# Altium schematic sheet CANBoard.SchDoc
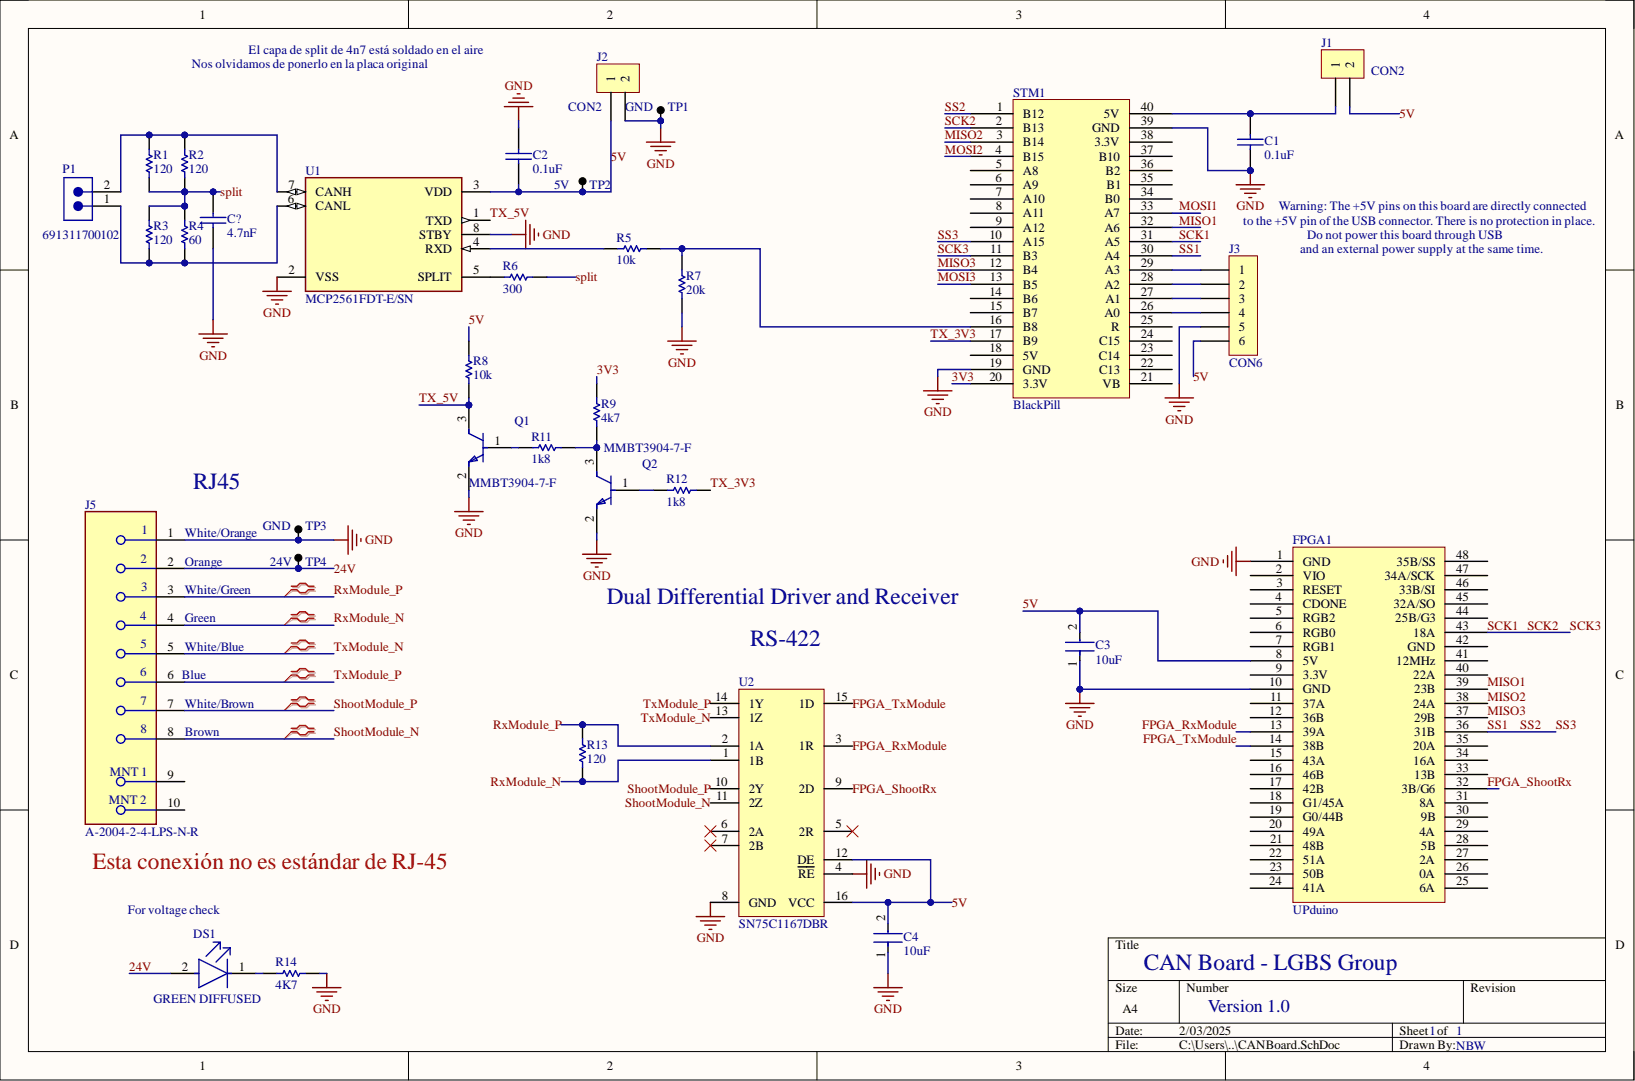
[diagram p0: CANBoard.SchDoc]

## Parts
C1  0.1uF  [0805]
C2  0.1uF  [0805]
C3  10uF  [FP-CL31B106KBHNNNE-IPC_A]
C4  10uF  [FP-CL31B106KBHNNNE-IPC_A]
C?  4.7nF  [0805]
DS1  GREEN DIFFUSED  [FP-LY_R976-MFG]
FPGA1  UPduino  [FPGA]
J1  CON2  [SIP2]
J2  CON2  [SIP2]
J3  CON6  [SIP6]
J5  A-2004-2-4-LPS-N-R  [FP-A-2004-2-4-LPS-N-R-MFG]
P1  691311700102  [691311700102]
Q1  MMBT3904-7-F  [FP-SOT23-IPC_A]
Q2  MMBT3904-7-F  [FP-SOT23-IPC_A]
R1  120  [0805]
R2  120  [0805]
R3  120  [0805]
R4  60  [0805]
R5  10k  [0805]
R6  300  [0805]
R7  20k  [0805]
R8  10k  [0805]
R9  4k7  [0805]
R11  1k8  [0805]
R12  1k8  [0805]
R13  120  [0805]
R14  4K7  [0805]
STM1  BlackPill  [BLACKPILL]
TP1  GND  [TPTH]
TP2  5V  [TPTH]
TP3  GND  [TPTH]
TP4  24V  [TPTH]
U1  MCP2561FDT-E/SN  [FP-C04-057-SN-MFG]
U2  SN75C1167DBR  [MC34050]

## Nets
GND: C1.2 C2.1 C3.1 C4.1 C?.2 FPGA1.1(GND) FPGA1.10(GND) J2.2 J3.5 J5.1 Q1.2 Q2.2 R7.2 R14.2 STM1.19(GND) STM1.39(GND) TP1.1 TP3.1 U1.2(VSS) U1.8(STBY) U2.4(RE) U2.8(GND)
3V3: R9.2 STM1.20(3.3V)
5V: C2.2 C3.2 C4.2 FPGA1.8(5V) J1.2 J2.1 J3.6 R8.2 TP2.1 U1.3(VDD) U2.12(DE) U2.16(VCC)
24V: DS1.2 J5.2 TP4.1
FPGA_RxModule: FPGA1.13(39A) U2.3(1R)
FPGA_ShootRx: FPGA1.32(3B/G6) U2.9(2D)
FPGA_TxModule: FPGA1.14(38B) U2.15(1D)
MISO1: FPGA1.39(23B) STM1.32(A6)
MISO2: FPGA1.38(24A) STM1.3(B14)
MISO3: FPGA1.37(29B) STM1.12(B4)
MOSI1: STM1.33(A7)
MOSI2: STM1.4(B15)
MOSI3: STM1.13(B5)
RxModule_N: J5.4 R13.1 U2.1(1B)
RxModule_P: J5.3 R13.2 U2.2(1A)
SCK1: FPGA1.43(18A) STM1.2(B13) STM1.11(B3) STM1.31(A5)
ShootModule_N: J5.8 U2.11(2Z)
ShootModule_P: J5.7 U2.10(2Y)
split: C?.1 R1.1 R2.1 R3.2 R4.2 R6.1
SS1: FPGA1.36(31B) STM1.1(B12) STM1.10(A15) STM1.30(A4)
TX_3V3: R12.2 STM1.17(B9)
TX_5V: Q1.3 R8.1 U1.1(TXD)
TxModule_N: J5.5 U2.13(1Z)
TxModule_P: J5.6 U2.14(1Y)
NetC1_1: C1.1 J1.1 STM1.40(5V)
NetDS1_1: DS1.1 R14.1
NetJ3_1: J3.1 STM1.29(A3)
NetJ3_2: J3.2 STM1.28(A2)
NetJ3_3: J3.3 STM1.27(A1)
NetJ3_4: J3.4 STM1.26(A0)
NetP1_1: P1.1 R3.1 R4.1 U1.6(CANL)
NetP1_2: P1.2 R1.2 R2.2 U1.7(CANH)
NetQ1_1: Q1.1 R11.2
NetQ2_1: Q2.1 R12.1
NetQ2_3: Q2.3 R9.1 R11.1
NetR5_1: R5.1 U1.4(RXD)
NetR5_2: R5.2 R7.1 STM1.16(B8)
NetR6_2: R6.2 U1.5(SPLIT)

Not connected: FPGA1 2(VIO) 3(RESET) 4(CDONE) 5(RGB2) 6(RGB0) 7(RGB1) 9(3.3V) 11(37A) 12(36B) 15(43A) 16(46B) 17(42B) 18(G1/45A) 19(G0/44B) 20(49A) 21(48B) 22(51A) 23(50B) 24(41A) 25(6A) 26(0A) 27(2A) 28(5B) 29(4A) 30(9B) 31(8A) 33(13B) 34(16A) 35(20A) 40(22A) 41(12MHz) 42(GND) 44(25B/G3) 45(32A/SO) 46(33B/SI) 47(34A/SCK) 48(35B/SS); J5 9 10; STM1 5(A8) 6(A9) 7(A10) 8(A11) 9(A12) 14(B6) 15(B7) 18(5V) 21(VB) 22(C13) 23(C14) 24(C15) 25(R) 34(B0) 35(B1) 36(B2) 37(B10) 38(3.3V); U2 5(2R) 6(2A) 7(2B)

## Library data of the parts
C1 C2 C? = CAP: Component Kind: Standard; Library Name: LIBEBAL.IntLib
C3 C4 = CMP-2000-07530-2: CAP CER 10UF 50V X7R 1206 -CL31B106KBHNNNE; Manufacturer: Samsung; Material: Ceramic; Temperature Coefficient: 15%; Tolerance: 10%; Automotive: No; Capacitance: 10uF; Category: Cap; Component Kind: Standard; ComponentLink2Description: Datasheet; ComponentLink2URL: http://www.samsungsem.com/global/front/downloadcms.do?path=%2F%2Fglobal%2F%2Fsupport%2F%2Fproduct-search%2F%2Fmlcc%2F%2F__icsFiles%2F%2Fafieldfile%2F%2F2019%2F%2F06%2F%2F18&fileName=CL31B106KBHNNNE-19.pdf; Device Class L1: Passive Components; Device Class L2: Capacitors; Device Class L3: Ceramic Capacitors; Digikey Description: CAP CER 10UF 50V X7R 1206; Height: 1.8mm; Lead Free: Yes; Library Name: Altium Content Vault; Manufacturer Part Number: CL31B106KBHNNNE; Octopart: https://octopart.com/cl31b106kbhnnne-samsung-30507377; Package: CL31B106KBHNNNE; RoHS: TRUE; Temperature: -55°C to +125°C; Temperature Characteristic: X7R; Temperature Range High: +125°C; Temperature Range Low: -55°C; Value: 10uF; Voltage Rating: 50V
DS1 = CMP-62016-000040-1: LED YELLOW DIFFUSED 0805 SMD - LY R976-PS-36; Dominant Wavelength: 588nm; Forward Current: 25mA; Forward Voltage: 2.5V; Lens Color: White; Lens Size: 1.25mm; Lens Style: Flat; Luminous Flux: 0.735lm; Luminous Intensity: 280mcd; Number of Elements: 1; Power Dissipation: 65mW; Reverse Voltage: 12V; Test Current: 20mA; Viewing Angle: 160°; Case Code (Imperial): 0805; Case Code (Metric): 2012; Case/Package: 0805; Color: Yellow; Component Kind: Standard; Depth: 1.35mm; Illumination Color: Yellow; Length: 2.1mm; Lens Transparency: Diffused; Library Name: Altium Content Vault; Max Operating Temperature: 85°C; Min Operating Temperature: -30°C; Mount: Surface Mount; Number of LEDs: 1; Number of Pins: 2; Package Quantity: 16000; Packaging: Tape and Reel; Radiation Hardening: No; Width: 1.25mm
FPGA1 = FPGA: upduino v3.0; Component Kind: Standard; Library Name: MCSI.SchLib
J5 = CMP-39752-000001-1: CONN MOD JACK 8P8C R/A SHIELDED; Direction: Down; Gender: Female; Max Current Rating: 1.5A; Max Voltage Rating (AC): 120V; Number of Contacts: 8; Number of Ports: 1; Pitch: 2.54mm; Component Kind: Standard; Connector Type: Jack; Contact Material: Bronze; Contact Plating: Gold; Housing Material: PBT; Lead Free: Lead Free; Library Name: Altium Content Vault; Max Operating Temperature: 85°C; Min Operating Temperature: -40°C; Mount: Through Hole; Number of Rows: 1; Orientation: Right Angle; Package Quantity: 100; Packaging: Tray; RoHS Compliant: Yes; Series: -; Shielding: Shielded; Termination: Solder
P1 = CMP-1502-02033-2: NexarPartId: 18818310; Current: 20A; Gender: Male; Number of Contacts: 2; Number of Levels: 1; Pitch: 5.0038mm; Voltage: 300V; Color: Green; Component Kind: Standard; Contact Material: Brass; Height: 12mm; Lead Pitch: 5mm; Length: 8.5mm; Library Name: Altium Content Vault; Mount: Through Hole; Number of Pins: 2; Number of Positions Per Level: 2; Number of Rows: 1; Orientation: Vertical; Packaging: Box; REACH SVHC: Unknown; RoHS: Compliant; Termination: Solder; Width: 12mm
Q1 Q2 = CMP-2000-04931-2: TRANS NPN 40V 0.2A SMD SOT23-3; Collector Base Voltage (VCBO): 60V; Collector Emitter Saturation Voltage: 300mV; Continuous Collector Current: 200mA; Element Configuration: Single; Emitter Base Voltage (VEBO): 6V; Frequency: 300MHz; hFE Min: 100; Max Power Dissipation: 300mW; Number of Elements: 1; Power Dissipation: 350mW; Case/Package: SOT-23; Collector Emitter Breakdown Voltage: 40V; Collector Emitter Voltage (VCEO): 40V; Component Kind: Standard; Contact Plating: Tin; Gain Bandwidth Product: 300MHz; Height: 1mm; Lead Free: Lead Free; Length: 3.05mm; Library Name: Altium Content Vault; Max Breakdown Voltage: 40V; Max Collector Current: 200mA; Max Frequency: 300MHz; Max Operating Temperature: 150°C; Min Operating Temperature: -55°C; Mount: Surface Mount; Number of Pins: 3; Package Quantity: 3000; Packaging: Tape and Reel; Polarity: NPN; Radiation Hardening: No; REACH SVHC: No SVHC; Transition Frequency: 300MHz; Voltage Rating (DC): 40V; Weight: 0.000282oz; Width: 1.4mm
R1-R9 R11-R13 = RES: Component Kind: Standard; Library Name: LIBEBAL.IntLib
R14 = RES: 1/8W; Component Kind: Standard; Library Name: LIBEBAL.IntLib
STM1 = STM32: STM32F411 BLACKPILL EVAL BRD; Component Kind: Standard; Library Name: MCSI.SchLib
TP1-TP4 = TP: Component Kind: Standard; Library Name: LIBEBAL.IntLib
U1 = CMP-06279-000064-1: IC TRANSCEIVER 1/1 8SOIC; Data Rate: 8Mbps; Max Supply Voltage: 5.5V; Min Supply Voltage: 4.5V; Number of Transceivers: 1; Operating Supply Current: 70mA; Receiver Hysteresis: 200mV; Case/Package: SOIC; Component Kind: Standard; Library Name: Altium Content Vault; Max Operating Temperature: 125°C; Min Operating Temperature: -40°C; Mount: Surface Mount; Number of Pins: 8; Package Quantity: 3300; Packaging: Tape and Reel; RoHS Compliant: Yes; Series: -; Weight: 0.019048oz
U2 = CMP-0310-00497-2: Dual Differential Driver and Receiver, 2 TX / 2 RX, 5V, 0 to 70 degC, 16-Pin SSOP (DB), Green (RoHS & no Sb/Br); Manufacturer: Texas Instruments; Drivers Per Package: 2; ICC(Max)(mA): 9; Rating: Catalog; SubFamily: RS-422; Supply Voltage(s)(V): 5; # of TX/RX: 2 TX / 2 RX; Code_JEDEC: MO-150; Component Kind: Standard; ComponentLink1Description: Datasheet; ComponentLink1URL: http://www.ti.com/general/docs/lit/getliterature.tsp?genericPartNumber=SN75C1167&fileType=pdf; ComponentLink2Description: Package Specification; ComponentLink2URL: http://www.ti.com/lit/ml/msso002e/msso002e.pdf; DatasheetVersion: SLLS159F; Eco-Plan: Green (RoHS & no Sb/Br); ESD(kV): 2; Library Name: Altium Content Vault; Mounting Technology: Surface Mount; PackageDescription: 16-Pin Plastic Small Outline Package, 6.2 x 5.3 mm Body, 0.65 mm Pitch; PackageReference: DB0016A; PackageVersion: revE, Dec-2001; PartNumber: SN75C1167DBR; Receivers Per Package: 2
J1 J2 = CON2: Component Kind: Standard; Library Name: LIBEBAL.IntLib
J3 = CON6: Component Kind: Standard; Library Name: LIBEBAL.IntLib
